# Altium schematic sheet [4]Actuators.SchDoc
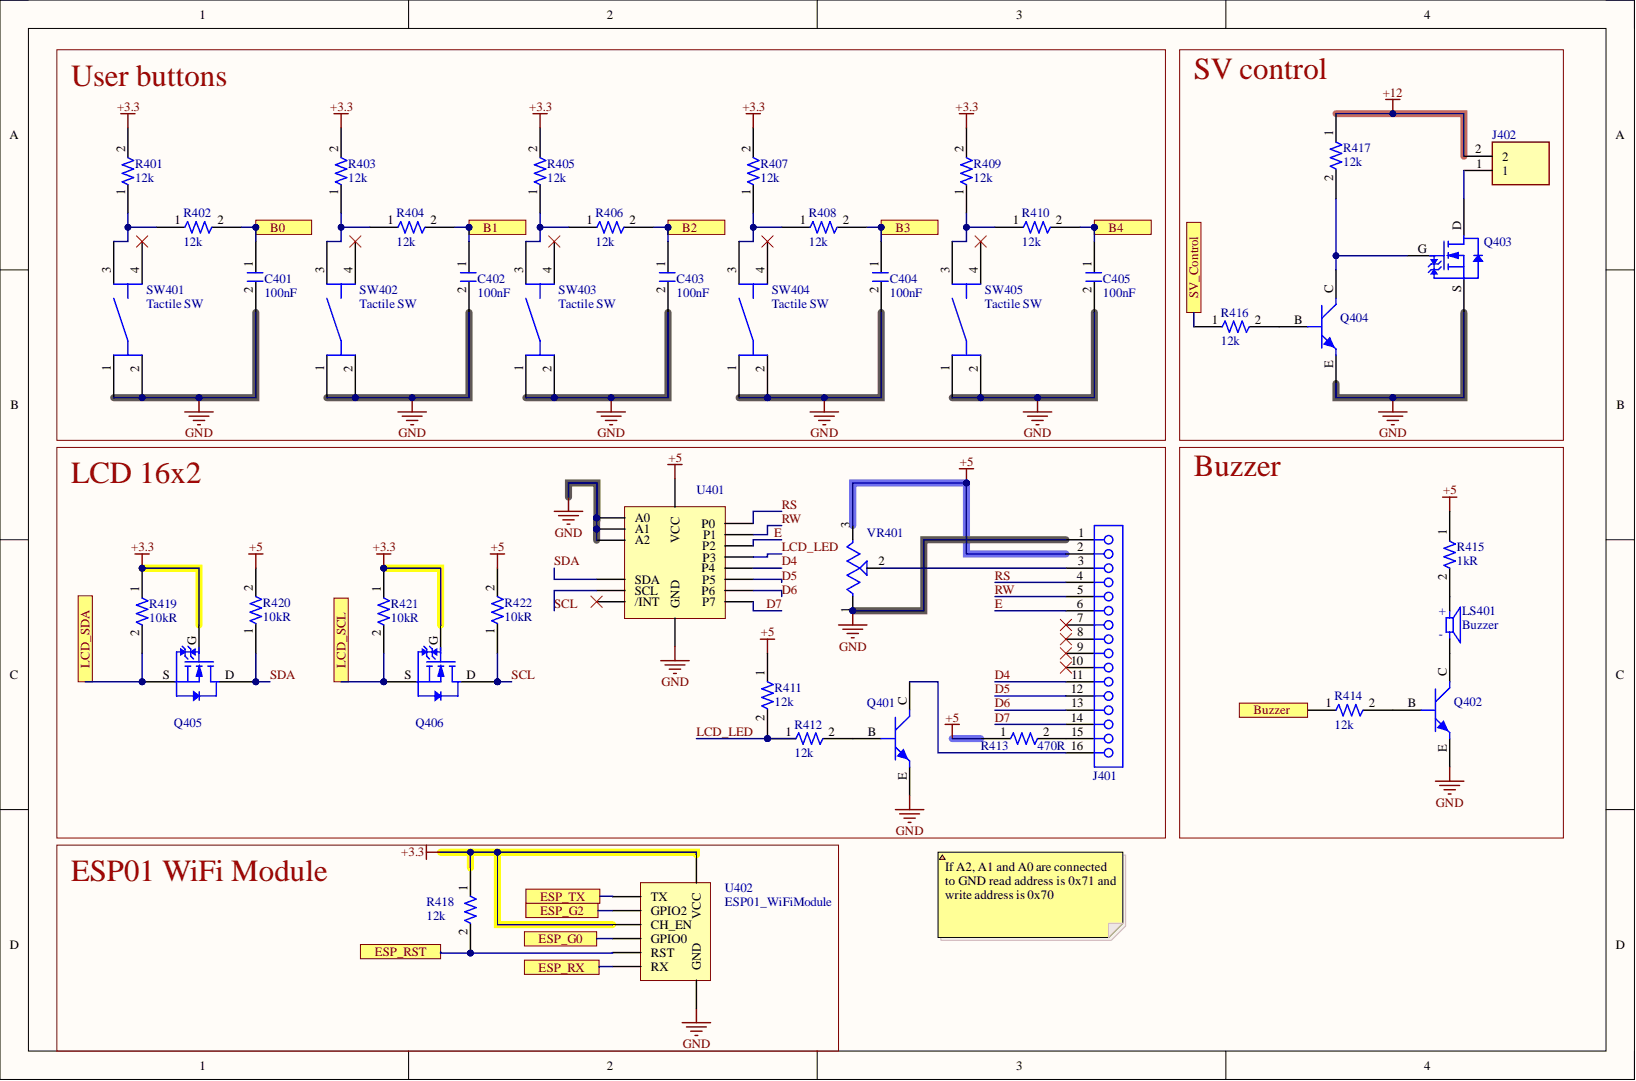
[diagram p0: [4]Actuators.SchDoc]

## Parts
C401  Capacitance: 100nF  [C1206FNPC-RW]  (hidden comment: 885012208009)
C402  Capacitance: 100nF  [C1206FNPC-RW]  (hidden comment: 885012208009)
C403  Capacitance: 100nF  [C1206FNPC-RW]  (hidden comment: 885012208009)
C404  Capacitance: 100nF  [C1206FNPC-RW]  (hidden comment: 885012208009)
C405  Capacitance: 100nF  [C1206FNPC-RW]  (hidden comment: 885012208009)
J401  16 SH  [SocketHeader_16_254]  (value not drawn)
J402  J_2_CableContact  [TE_282834-2]  (value not drawn)
LS401  Buzzer  [CUI_CMI-1275C-050]
Q401  BC817-25LT1G  [TRT-NPN-0.5/45-SOT23]  (value not drawn)
Q402  BC817-25LT1G  [TRT-NPN-0.5/45-SOT23]  (value not drawn)
Q403  TRT_NCH_FDN327N  [SuperSOT3]  (value not drawn)
Q404  BC817-25LT1G  [TRT-NPN-0.5/45-SOT23]  (value not drawn)
Q405  TRT_NCH_FDN327N  [SuperSOT3]  (value not drawn)
Q406  TRT_NCH_FDN327N  [SuperSOT3]  (value not drawn)
R401  12k  [R1206]
R402  12k  [R1206]
R403  12k  [R1206]
R404  12k  [R1206]
R405  12k  [R1206]
R406  12k  [R1206]
R407  12k  [R1206]
R408  12k  [R1206]
R409  12k  [R1206]
R410  12k  [R1206]
R411  12k  [R1206]
R412  12k  [R1206]
R413  Resistance: 470R  [R0805FTK]  (hidden comment: CR0805-FX-4700GLF)
R414  12k  [R1206]
R415  Resistance: 1kR  [R0805FTK]  (hidden comment: CR0805-JW-102ELF)
R416  12k  [R1206]
R417  12k  [R1206]
R418  12k  [R1206]
R419  Resistance: 10kR  [R0805FTK]  (hidden comment: CR0805-JW-103ELF)
R420  Resistance: 10kR  [R0805FTK]  (hidden comment: CR0805-JW-103ELF)
R421  Resistance: 10kR  [R0805FTK]  (hidden comment: CR0805-JW-103ELF)
R422  Resistance: 10kR  [R0805FTK]  (hidden comment: CR0805-JW-103ELF)
SW401  Tactile SW  [SW_2-1437565-7]
SW402  Tactile SW  [SW_2-1437565-7]
SW403  Tactile SW  [SW_2-1437565-7]
SW404  Tactile SW  [SW_2-1437565-7]
SW405  Tactile SW  [SW_2-1437565-7]
U401  PCF8574ADW_I2C_8bit_I/O_Expander  [PCF8574A_SOIC16]  (value not drawn)
U402  ESP01_WiFiModule  [Dual socket header 8 pins]
VR401  Trimmer_10k_THT  [TRIM_3362P-1-103LF]  (value not drawn)

## Ports
B0, B1, B2, B3, B4, Buzzer, ESP_G0, ESP_G2, ESP_RST, ESP_RX, ESP_TX, LCD_SCL, LCD_SDA, SV_Control

## Nets
+3.3: Q405.G Q406.G R401.2 R403.2 R405.2 R407.2 R409.2 R418.1 R419.1 R421.1 U402.4(CH_EN) U402.8(VCC)
+5: J401.2 R411.1 R413.1 R415.1 R420.2 R422.2 U401.16(VCC) VR401.3
+12: J402.2 R417.1
GND: C401.2 C402.2 C403.2 C404.2 C405.2 J401.1 Q401.E Q402.E Q403.S Q404.E SW401.1 SW401.2 SW402.1 SW402.2 SW403.1 SW403.2 SW404.1 SW404.2 SW405.1 SW405.2 U401.1(A0) U401.2(A1) U401.3(A2) U401.8(GND) U402.1(GND) VR401.1
B0: C401.1 R402.2 ; port B0
B1: C402.1 R404.2 ; port B1
B2: C403.1 R406.2 ; port B2
B3: C404.1 R408.2 ; port B3
B4: C405.1 R410.2 ; port B4
Buzzer: R414.1 ; port Buzzer
D4: J401.11 U401.9(P4)
D5: J401.12 U401.10(P5)
D6: J401.13 U401.11(P6)
D7: J401.14 U401.12(P7)
E: J401.6 U401.6(P2)
ESP_G0: U402.5(GPIO0) ; port ESP_G0
ESP_G2: U402.3(GPIO2) ; port ESP_G2
ESP_RST: R418.2 U402.6(RST) ; port ESP_RST
ESP_RX: U402.7(RX) ; port ESP_RX
ESP_TX: U402.2(TX) ; port ESP_TX
LCD_LED: R411.2 R412.1 U401.7(P3)
LCD_SCL: Q406.S R421.2 ; port LCD_SCL
LCD_SDA: Q405.S R419.2 ; port LCD_SDA
RS: J401.4 U401.4(P0)
RW: J401.5 U401.5(P1)
SCL: Q406.D R422.1 U401.14(SCL)
SDA: Q405.D R420.1 U401.15(SDA)
SV_Control: R416.1 ; port SV_Control
NetJ401_3: J401.3 VR401.2
NetJ401_7: J401.7
NetJ401_8: J401.8
NetJ401_9: J401.9
NetJ401_10: J401.10
NetJ401_15: J401.15 R413.2
NetJ401_16: J401.16 Q401.C
NetJ402_1: J402.1 Q403.D
NetLS401_N: LS401.N Q402.C
NetLS401_P: LS401.P R415.2
NetQ401_B: Q401.B R412.2
NetQ402_B: Q402.B R414.2
NetQ403_G: Q403.G Q404.C R417.2
NetQ404_B: Q404.B R416.2
NetR401_1: R401.1 R402.1 SW401.3
NetR403_1: R403.1 R404.1 SW402.3
NetR405_1: R405.1 R406.1 SW403.3
NetR407_1: R407.1 R408.1 SW404.3
NetR409_1: R409.1 R410.1 SW405.3
NetSW401_4: SW401.4
NetSW402_4: SW402.4
NetSW403_4: SW403.4
NetSW404_4: SW404.4
NetSW405_4: SW405.4
NetU401_13: U401.13(/INT)

## Library data of the parts
C401-C405 = C100n-10V-1206FNPC-X7R: Cap, 0.1ΜF, 10V, 10%, X7R, 1206; Manufacturer 1: Wurth Electronics; .Creator: GP; Case Code (Imperial): 1206; Case Code (Metric): 3216; Case/Package: 1206; Category: Ceramic Capacitors; Component Kind: Standard; ComponentLink1Description: http://www.farnell.com/datasheets/2031891.pdf?src-supplier=Farnell; ComponentLink1URL: http://www.farnell.com/datasheets/2031891.pdf?src-supplier=Farnell; ComponentLink2Description: http://katalog.we-online.de/pbs/datasheet/885012208009.pdf?src-supplier=Digi-Key; ComponentLink2URL: http://katalog.we-online.de/pbs/datasheet/885012208009.pdf?src-supplier=Digi-Key; ComponentLink3Description: https://katalog.we-online.de/pbs/datasheet/885012208009.pdf?src-supplier=Digi-Key; ComponentLink3URL: https://katalog.we-online.de/pbs/datasheet/885012208009.pdf?src-supplier=Digi-Key; ComponentLink4Description: Wurth Elektronik; ComponentLink4URL: https://www.we-online.com/catalog/en/WCAP-CSGP-10VDC?sq=885012208009#885012208009; Length: 0.126inch; Library Name: Passives.SchLib; Manufacturer Part Number 1: 885012208009; Max Operating Temperature: 125°C; Min Operating Temperature: -55°C; Mount: Surface Mount; Packaging: Tape and Reel; Pricing 1: 1=0,11000, 10=0,10300, 100=0,09200, 500=0,08100 (USD); RoHS: Yes; RoHS Compliant: Yes; Stock 1: 7198; Supplier 1: Digi-Key; Supplier Part Number 1: 732-8086-1-ND; Thickness: 0.035inch; Tolerance: 10%; Voltage Rating: 10V; Width: 0.063inch
J401 = Socket_Header_16_2.54: WR-PHD Socket Header, THT, Vertical, pitch 2.54mm, 1 Row, 16P; Manufacturer: Wurth Elektronik; Manufacturer Part Number: 61301611821; Contact Resistance: 20mΩ; Rated Current: 3A; Withstanding Voltage: 500V; Working Voltage: 250V; Category: Board-to-Board Connectors; Component Kind: Standard; ComponentLink1Description: Website Link; ComponentLink1URL: https://www.we-online.com/redexpert/article/61301611821?ad; ComponentLink2Description: Datasheet Link; ComponentLink2URL: https://www.we-online.com/redexpert/spec/61301611821?ad; Gender: Socket Header; Match Code: WR-PHD; Mount: Through Hole; Number of Pins: 16; Number of Rows: 1; Operating Temperature Max: 125°C; Operating Temperature Min: -40°C; Orientation: Vertical; Packaging: Tray; Pitch: 2.54mm; Library Name: CommonFiles_Library.SchLib
J402 = J_2_CableContact: MF: {'manufacturer': u'TE Connectivity'}; MP: 282834-2; Availability: Unavailable; Component Kind: Standard; Package: None; Price: None; Library Name: CommonFiles_Library.SchLib
LS401 = Buzzer_CMI-1275C-050: 12 mm, 5 Vdc, 90 dB, 3.1 kHz, Through Hole, Magnetic Audio Indicator Buzzer; MF: CUI Devices; Availability: Unavailable; Component Kind: Standard; CUI_purchase_URL: https://www.cuidevices.com/product/audio/buzzers/audio-indicators/cmi-1275c-050?utm_source=snapeda.com&utm_medium=referral&utm_campaign=snapedaBOM; DigiKey-Purchase-URL: https://snapeda.com/shop?store=DigiKey&id=2772244; Mouser-Purchase-URL: https://snapeda.com/shop?store=Mouser&id=2772244; MP: CMI-1275C-050; Package: TO-220 CUI Inc.; Price: None; SnapEDA_Link: https://www.snapeda.com/parts/CMI-1275C-050/CUI%20Devices/view-part/2772244/?ref=snap; Library Name: CommonFiles_Library.SchLib
Q401 Q402 Q404 = TRT-NPN-0.5/45-SOT23: ON Semi BC817-25LT1G NPN Bipolar Transistor; 0.5 A; 45 V; 3-Pin SOT-23; .Creator: GP; Collector Base Voltage (VCBO): 50V; Collector Emitter Breakdown Voltage: 45V; Collector Emitter Saturation Voltage: 700mV; Current Rating: 500mA; Element Configuration: Single; Emitter Base Voltage (VEBO): 5V; Frequency: 100MHz; hFE Min: 160; Number of Elements: 1; Power Dissipation: 300mW; Case/Package: SOT-23-3; Category: Transistor; Collector Emitter Voltage (VCEO): 45V; Collector-emitter Voltage-Max: 700mV; Component Kind: Standard; ComponentLink1Description: Datasheet; ComponentLink2Description: Where buy it; ComponentLink3Description: https://datasheet.ciiva.com/27748/2010413-27748829.pdf?src-supplier=Jameco; ComponentLink3URL: https://datasheet.ciiva.com/27748/2010413-27748829.pdf?src-supplier=Jameco; ComponentLink4Description: https://datasheet.ciiva.com/15138/getdatasheetpartid-38405-15138602.pdf?src-supplier=Verical; ComponentLink4URL: https://datasheet.ciiva.com/15138/getdatasheetpartid-38405-15138602.pdf?src-supplier=Verical; ComponentLink5Description: https://datasheet.ciiva.com/1196/bc817-16lt1-d-1196558.pdf?src-supplier=Element14; ComponentLink5URL: https://datasheet.ciiva.com/1196/bc817-16lt1-d-1196558.pdf?src-supplier=Element14; ComponentLink6Description: https://datasheet.ciiva.com/22641/bc817-16lt1-d-22641013.pdf?src-supplier=Farnell; ComponentLink6URL: https://datasheet.ciiva.com/22641/bc817-16lt1-d-22641013.pdf?src-supplier=Farnell; ComponentLink7Description: https://datasheet.ciiva.com/22664/bc817-16lt1-d-22664204.pdf?src-supplier=Element14; ComponentLink7URL: https://datasheet.ciiva.com/22664/bc817-16lt1-d-22664204.pdf?src-supplier=Element14; ComponentLink8Description: https://datasheet.ciiva.com/22664/1842041-22664101.pdf?src-supplier=Element14; ComponentLink8URL: https://datasheet.ciiva.com/22664/1842041-22664101.pdf?src-supplier=Element14; ComponentLink9Description: https://datasheet.ciiva.com/4722/bc817-16lt1-d-104560-4722229.pdf?src-supplier=Mouser; ComponentLink9URL: https://datasheet.ciiva.com/4722/bc817-16lt1-d-104560-4722229.pdf?src-supplier=Mouser; ComponentLink10Description: https://datasheet.ciiva.com/1195/bc817-16lt1-d-1195763.pdf?src-supplier=Element14; ComponentLink10URL: https://datasheet.ciiva.com/1195/bc817-16lt1-d-1195763.pdf?src-supplier=Element14; ComponentLink11Description: https://datasheet.ciiva.com/1195/bc817-16lt1-d-1195764.pdf?src-supplier=Element14; ComponentLink11URL: https://datasheet.ciiva.com/1195/bc817-16lt1-d-1195764.pdf?src-supplier=Element14; ComponentLink12Description: https://datasheet.ciiva.com/11197/bc817-16lt1-d-11197514.pdf?src-supplier=Avnet; ComponentLink12URL: https://datasheet.ciiva.com/11197/bc817-16lt1-d-11197514.pdf?src-supplier=Avnet; ComponentLink13Description: https://datasheet.ciiva.com/25996/bc817-16lt1-d-25996327.pdf?src-supplier=Avnet+Europe; ComponentLink13URL: https://datasheet.ciiva.com/25996/bc817-16lt1-d-25996327.pdf?src-supplier=Avnet+Europe; ComponentLink14Description: https://datasheet.ciiva.com/976/bc817-16lt1-d-976357.pdf?src-supplier=Digi-Key; ComponentLink14URL: https://datasheet.ciiva.com/976/bc817-16lt1-d-976357.pdf?src-supplier=Digi-Key; ComponentLink15Description: https://datasheet.ciiva.com/976/bc817-16lt1-d-976358.pdf?src-supplier=Digi-Key; ComponentLink15URL: https://datasheet.ciiva.com/976/bc817-16lt1-d-976358.pdf?src-supplier=Digi-Key; ComponentLink16Description: https://datasheet.ciiva.com/976/bc817-16lt1-d-976356.pdf?src-supplier=Digi-Key; ComponentLink16URL: https://datasheet.ciiva.com/976/bc817-16lt1-d-976356.pdf?src-supplier=Digi-Key; Contact Plating: Tin,Matte; Gain Bandwidth Product: 100MHz; Halogen Free: Halogen Free; Height: 0.94mm; Lead Free: Lead Free; Length: 2.9mm; Library Name: Semiconductor.SchLib; Max Breakdown Voltage: 45V; Max Collector Current: 500mA; Max Frequency: 100MHz; Number of Pins: 3; Package Quantity: 1; Pricing 1: 1=0,20000, 10=0,18500, 100=0,10080, 500=0,06204, 1000=0,04229 (USD); Radiation Hardening: No; REACH SVHC: No SVHC; RoHS Compliant: Yes; Series: -; Supplier 1: Digi-Key; Supplier Part Number 1: BC817-25LT1GOSCT-ND; Transition Frequency: 100MHz; Width: 1.3mm
R401-R412 R414 R416-R418 = R12k-1206: Component Kind: Standard; Library Name: CommonFiles_Library.SchLib
R413 = R470-0805FTK: Res Thick Film 0805 470 Ohm 1% 1/8W ±100ppm/°C Molded SMD SMD Paper Tape on Plastic Reel; Manufacturer 1: Bourns; .Creator: DR; Max Power Dissipation: 125mW; Voltage Rating: 150V; Case Code (Imperial): 0805; Case Code (Metric): 2012; Case/Package: 0805; Category: Chip SMD Resistors; Component Kind: Standard; ComponentLink1Description: https://datasheet.ciiva.com/11808/0900766b80fcdb72-11808686.pdf?src-supplier=RSComponents; ComponentLink1URL: https://datasheet.ciiva.com/11808/0900766b80fcdb72-11808686.pdf?src-supplier=RSComponents; ComponentLink2Description: https://datasheet.ciiva.com/7985/1856384-7985880.pdf?src-supplier=Element14; ComponentLink2URL: https://datasheet.ciiva.com/7985/1856384-7985880.pdf?src-supplier=Element14; ComponentLink3Description: https://datasheet.ciiva.com/22693/1902866-22693247.pdf?src-supplier=Element14; ComponentLink3URL: https://datasheet.ciiva.com/22693/1902866-22693247.pdf?src-supplier=Element14; ComponentLink4Description: https://datasheet.ciiva.com/5541/chpreztr-5541930.pdf?src-supplier=Mouser; ComponentLink4URL: https://datasheet.ciiva.com/5541/chpreztr-5541930.pdf?src-supplier=Mouser; Construction: Rectangular; Contact Plating: Tin; Depth: 1.25mm; Features: Moisture Resistant; Height: 0.5mm; Length: 2mm; Library Name: Passives.SchLib; Manufacturer Part Number 1: CR0805-FX-4700GLF; Max Operating Temperature: 155°C; Military Standard: Not; Min Operating Temperature: -55°C; Mount: Surface Mount; Number of Pins: 2; Number of Terminations: 2; Packaging: Tape and Reel; Power Rating: 125mW; Pricing 1: 1=0,00990, 10=0,00980, 25=0,00950, 50=0,00940, 100=0,00930, 250=0,00920, 500=0,00910, 1000=0,00890, 3000=0,00870, 6000=0,00860 (USD); Radiation Hardening: No; REACH SVHC: No SVHC; Resistance Tolerance: 1%; Resistor Type: Thick Film; RoHS: Yes; Series: CR0805; Stock 1: 12623; Supplier 1: Arrow Electronics; Supplier Part Number 1: CR0805-FX-4700GLF; Technology: THICK FILM; Temperature Coefficient: 100ppm/°C; Termination: SMD/SMT; Thickness: 508um; Tolerance: 1%; Voltage Rating (DC): 150V
R415 = R1k-0805FTK: Res Thick Film 0805 1K Ohm 5% 1/8W ±200ppm/°C Molded SMD SMD Paper Tape on Plastic Reel; Manufacturer 1: Bourns; .Creator: GP; Max Power Dissipation: 125mW; Voltage Rating: 150V; Case Code (Imperial): 0805; Case Code (Metric): 2012; Case/Package: 0805; Category: Chip SMD Resistors; Component Kind: Standard; ComponentLink1Description: https://datasheet.ciiva.com/11821/0900766b80fcdb72-11821134.pdf?src-supplier=RSComponents; ComponentLink1URL: https://datasheet.ciiva.com/11821/0900766b80fcdb72-11821134.pdf?src-supplier=RSComponents; ComponentLink2Description: https://datasheet.ciiva.com/15225/getdatasheetpartid-78155-15225381.pdf?src-supplier=Verical; ComponentLink2URL: https://datasheet.ciiva.com/15225/getdatasheetpartid-78155-15225381.pdf?src-supplier=Verical; ComponentLink3Description: https://datasheet.ciiva.com/22693/1902866-22693253.pdf?src-supplier=Element14; ComponentLink3URL: https://datasheet.ciiva.com/22693/1902866-22693253.pdf?src-supplier=Element14; ComponentLink4Description: https://datasheet.ciiva.com/7986/1856384-7986184.pdf?src-supplier=Element14; ComponentLink4URL: https://datasheet.ciiva.com/7986/1856384-7986184.pdf?src-supplier=Element14; ComponentLink5Description: https://datasheet.ciiva.com/7985/1856384-7985867.pdf?src-supplier=Element14; ComponentLink5URL: https://datasheet.ciiva.com/7985/1856384-7985867.pdf?src-supplier=Element14; ComponentLink6Description: https://datasheet.ciiva.com/30242/159chpreztr-30242765.pdf?src-supplier=Onlinecomponents.com; ComponentLink6URL: https://datasheet.ciiva.com/30242/159chpreztr-30242765.pdf?src-supplier=Onlinecomponents.com; ComponentLink7Description: https://datasheet.ciiva.com/6547/chpreztr-6547359.pdf?src-supplier=Digi-Key; ComponentLink7URL: https://datasheet.ciiva.com/6547/chpreztr-6547359.pdf?src-supplier=Digi-Key; Contact Plating: Tin; Depth: 1.25mm; Features: Moisture Resistant; Height: 0.5mm; Lead Free: Lead Free; Length: 2mm; Library Name: Passives.SchLib; Manufacturer Part Number 1: CR0805-JW-102ELF; Max Operating Temperature: 155°C; Military Standard: Not; Min Operating Temperature: -55°C; Mount: Surface Mount; Number of Pins: 2; Number of Terminations: 2; Package Quantity: 1; Packaging: Tape and Reel; Power Rating: 125mW; Pricing 1: 1=0,05930, 10=0,03510, 25=0,01790, 100=0,00800, 250=0,00790, 500=0,00590, 1000=0,00360, 3000=0,00350, 6000=0,00250 (USD); Radiation Hardening: No; REACH SVHC: No SVHC; Resistor Type: Thick Film; RoHS: Yes; Stock 1: 27510; Supplier 1: Arrow Electronics; Supplier Part Number 1: CR0805-JW-102ELF; Temperature Coefficient: 200ppm/°C; Termination: SMD/SMT; Thickness: 508um; Tolerance: 5%; Voltage Rating (DC): 150V; Width: 1.25mm
R419-R422 = R10k-0805FTK: BOURNS         CR0805-JW-103ELF            Surface Mount Chip Resistor, Thick Film, CR Series, 10 kohm, 125 mW,  5%, 150 V; .Creator: DR; Max Power Dissipation: 125mW; Temperature Coefficient: 200ppm/°C; Voltage Rating: 150V; Voltage Rating (DC): 100V; Case Code (Imperial): 0805; Case Code (Metric): 2012; Case/Package: 0805; Category: Chip SMD Resistors; Component Kind: Standard; ComponentLink1Description: https://datasheet.ciiva.com/11821/0900766b80fcdb72-11821135.pdf?src-supplier=RSComponents; ComponentLink1URL: https://datasheet.ciiva.com/11821/0900766b80fcdb72-11821135.pdf?src-supplier=RSComponents; ComponentLink2Description: https://datasheet.ciiva.com/9122/chpreztr-9122374.pdf?src-supplier=Avnet; ComponentLink2URL: https://datasheet.ciiva.com/9122/chpreztr-9122374.pdf?src-supplier=Avnet; ComponentLink3Description: https://datasheet.ciiva.com/22693/1902866-22693254.pdf?src-supplier=Element14; ComponentLink3URL: https://datasheet.ciiva.com/22693/1902866-22693254.pdf?src-supplier=Element14; ComponentLink4Description: https://datasheet.ciiva.com/7986/691949-7986187.pdf?src-supplier=Element14; ComponentLink4URL: https://datasheet.ciiva.com/7986/691949-7986187.pdf?src-supplier=Element14; ComponentLink5Description: https://datasheet.ciiva.com/30065/159chpreztr-30065976.pdf?src-supplier=Onlinecomponents.com; ComponentLink5URL: https://datasheet.ciiva.com/30065/159chpreztr-30065976.pdf?src-supplier=Onlinecomponents.com; ComponentLink6Description: https://datasheet.ciiva.com/16783/70154685-16783482.pdf?src-supplier=Allied+Electronics; ComponentLink6URL: https://datasheet.ciiva.com/16783/70154685-16783482.pdf?src-supplier=Allied+Electronics; ComponentLink7Description: https://datasheet.ciiva.com/5548/chpreztr-5548491.pdf?src-supplier=Mouser; ComponentLink7URL: https://datasheet.ciiva.com/5548/chpreztr-5548491.pdf?src-supplier=Mouser; ComponentLink8Description: https://datasheet.ciiva.com/6559/chpreztr-6559900.pdf?src-supplier=Digi-Key; ComponentLink8URL: https://datasheet.ciiva.com/6559/chpreztr-6559900.pdf?src-supplier=Digi-Key; ComponentLink9Description: https://datasheet.ciiva.com/6559/chpreztr-6559901.pdf?src-supplier=Digi-Key; ComponentLink9URL: https://datasheet.ciiva.com/6559/chpreztr-6559901.pdf?src-supplier=Digi-Key; ComponentLink10Description: https://datasheet.ciiva.com/7985/1856384-7985869.pdf?src-supplier=Element14; ComponentLink10URL: https://datasheet.ciiva.com/7985/1856384-7985869.pdf?src-supplier=Element14; ComponentLink11Description: https://datasheet.ciiva.com/48692/001055517-da-01-en-smd-wid-cr0805-jw-103elf-10k-ohm-1-8w-48692118.pdf?src-supplier=Conrad; ComponentLink11URL: https://datasheet.ciiva.com/48692/001055517-da-01-en-smd-wid-cr0805-jw-103elf-10k-ohm-1-8w-48692118.pdf?src-supplier=Conrad; Construction: Rectangular; Contact Plating: Tin; Depth: 1.25mm; Features: Moisture Resistant; Height: 0.5mm; Length: 2mm; Library Name: Passives.SchLib; Manufacturer 1: Bourns; Manufacturer Part Number 1: CR0805-JW-103ELF; Max Operating Temperature: 155°C; Military Standard: Not; Min Operating Temperature: -55°C; Mount: Surface Mount; Number of Pins: 2; Number of Terminations: 2; Package Quantity: 1; Packaging: Tape and Reel; Power Rating: 125mW; Pricing 1: 1=0,05790, 10=0,01540, 25=0,01340, 100=0,00340 (USD); Radiation Hardening: No; REACH SVHC: No SVHC; Resistance Tolerance: 5%; Resistor Type: Thick Film; RoHS: Yes; RoHS Compliant: Yes; Series: CR0805; Stock 1: 57566; Supplier 1: Arrow Electronics; Supplier Part Number 1: CR0805-JW-103ELF; Technology: THICK FILM; Termination: SMD/SMT; Thickness: 508um; Tolerance: 5%; Width: 1.25mm
SW401-SW405 = SW_6x6: Component Kind: Standard; Library Name: CommonFiles_Library.SchLib
VR401 = Trimmer_10k_THT: Trimmer, Cermet, Rest 10 Kilohms, PCB, 1 Turns, Pwr-Rtg 0.5 W, Tol 10% | Bourns 3362P-1-103LF; Check_prices: https://www.snapeda.com/parts/3362P-1-103LF/Bourns/view-part/?ref=eda; Component Kind: Standard; MF: Bourns; MP: 3362P-1-103LF; Package: 3362P-1 Bourns Inc.; SnapEDA_Link: https://www.snapeda.com/parts/3362P-1-103LF/Bourns/view-part/?ref=snap; Library Name: CommonFiles_Library.SchLib
Q403 Q405 Q406 = TRT_NCH_FDN327N: Component Kind: Standard; Library Name: CommonFiles_Library.SchLib
U401 = PCF8574ADW_I2C_8bit_I/O_Expander: Component Kind: Standard; Library Name: CommonFiles_Library.SchLib
U402 = ESP01_WiFiModule: Component Kind: Standard; Library Name: CommonFiles_Library.SchLib
